# Altium schematic sheet USB3_Device.SchDoc
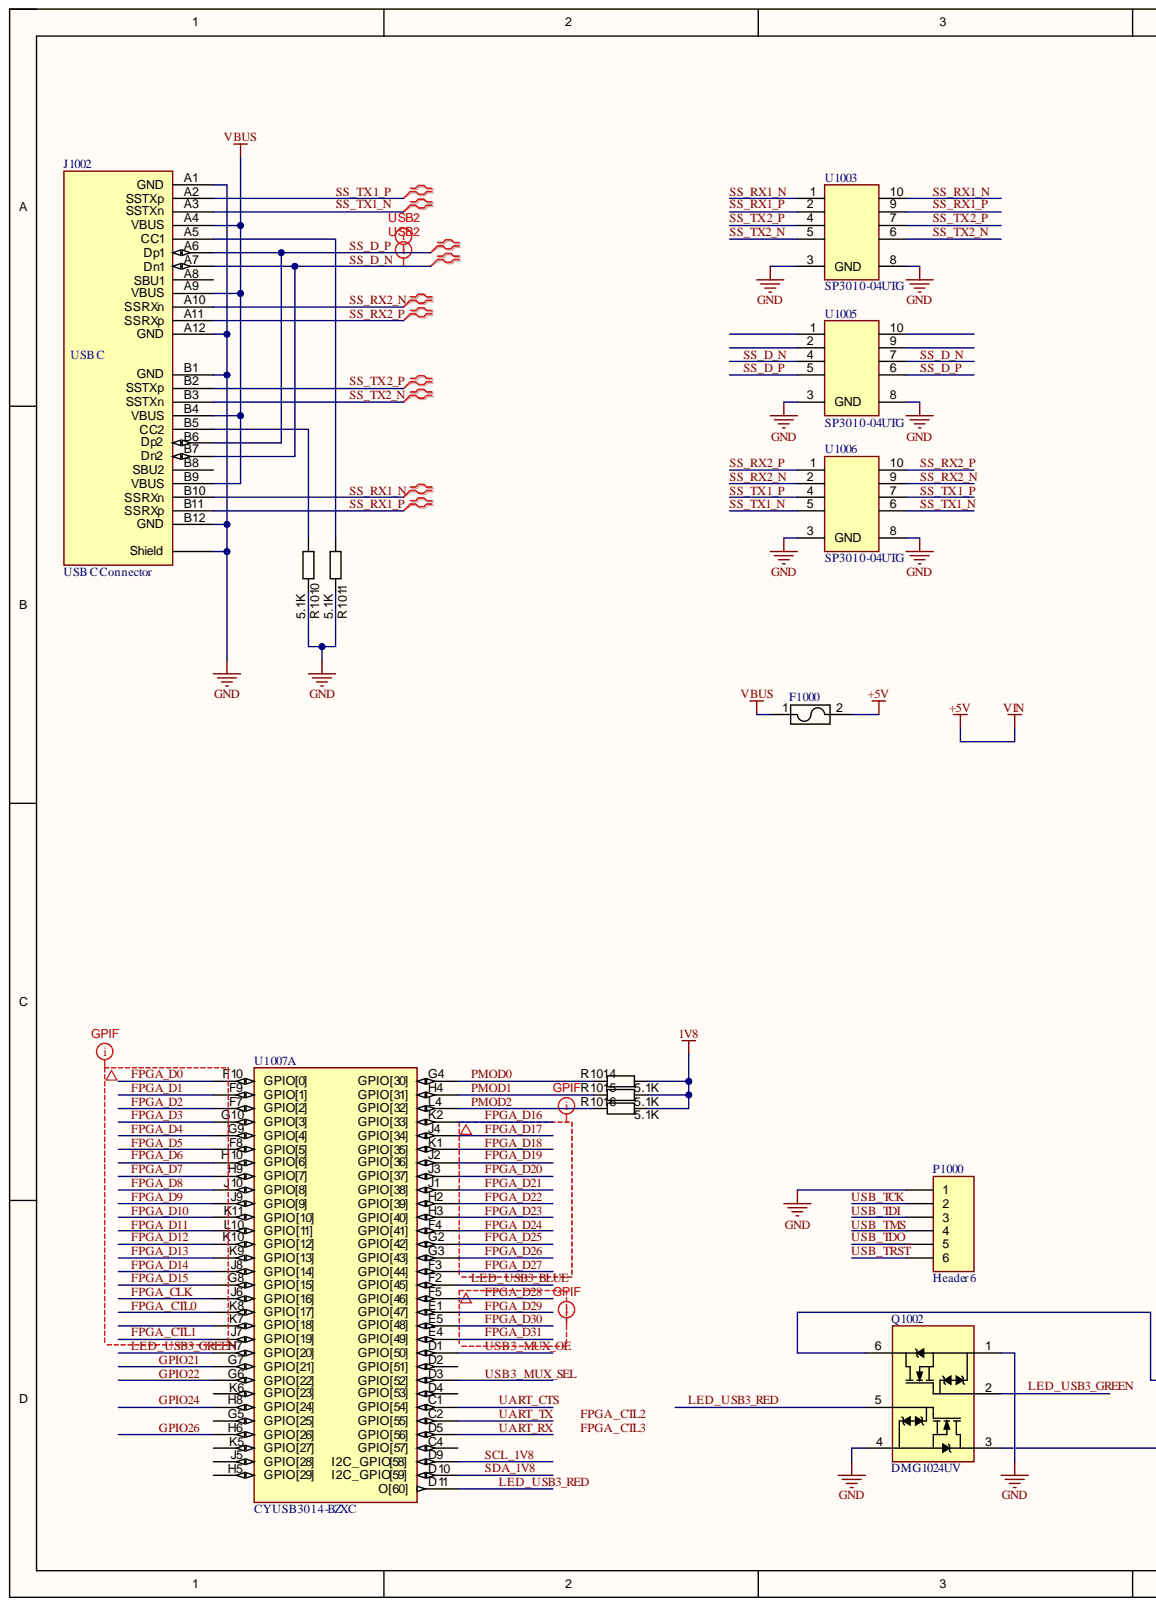
[diagram p0: USB3_Device.SchDoc, left]
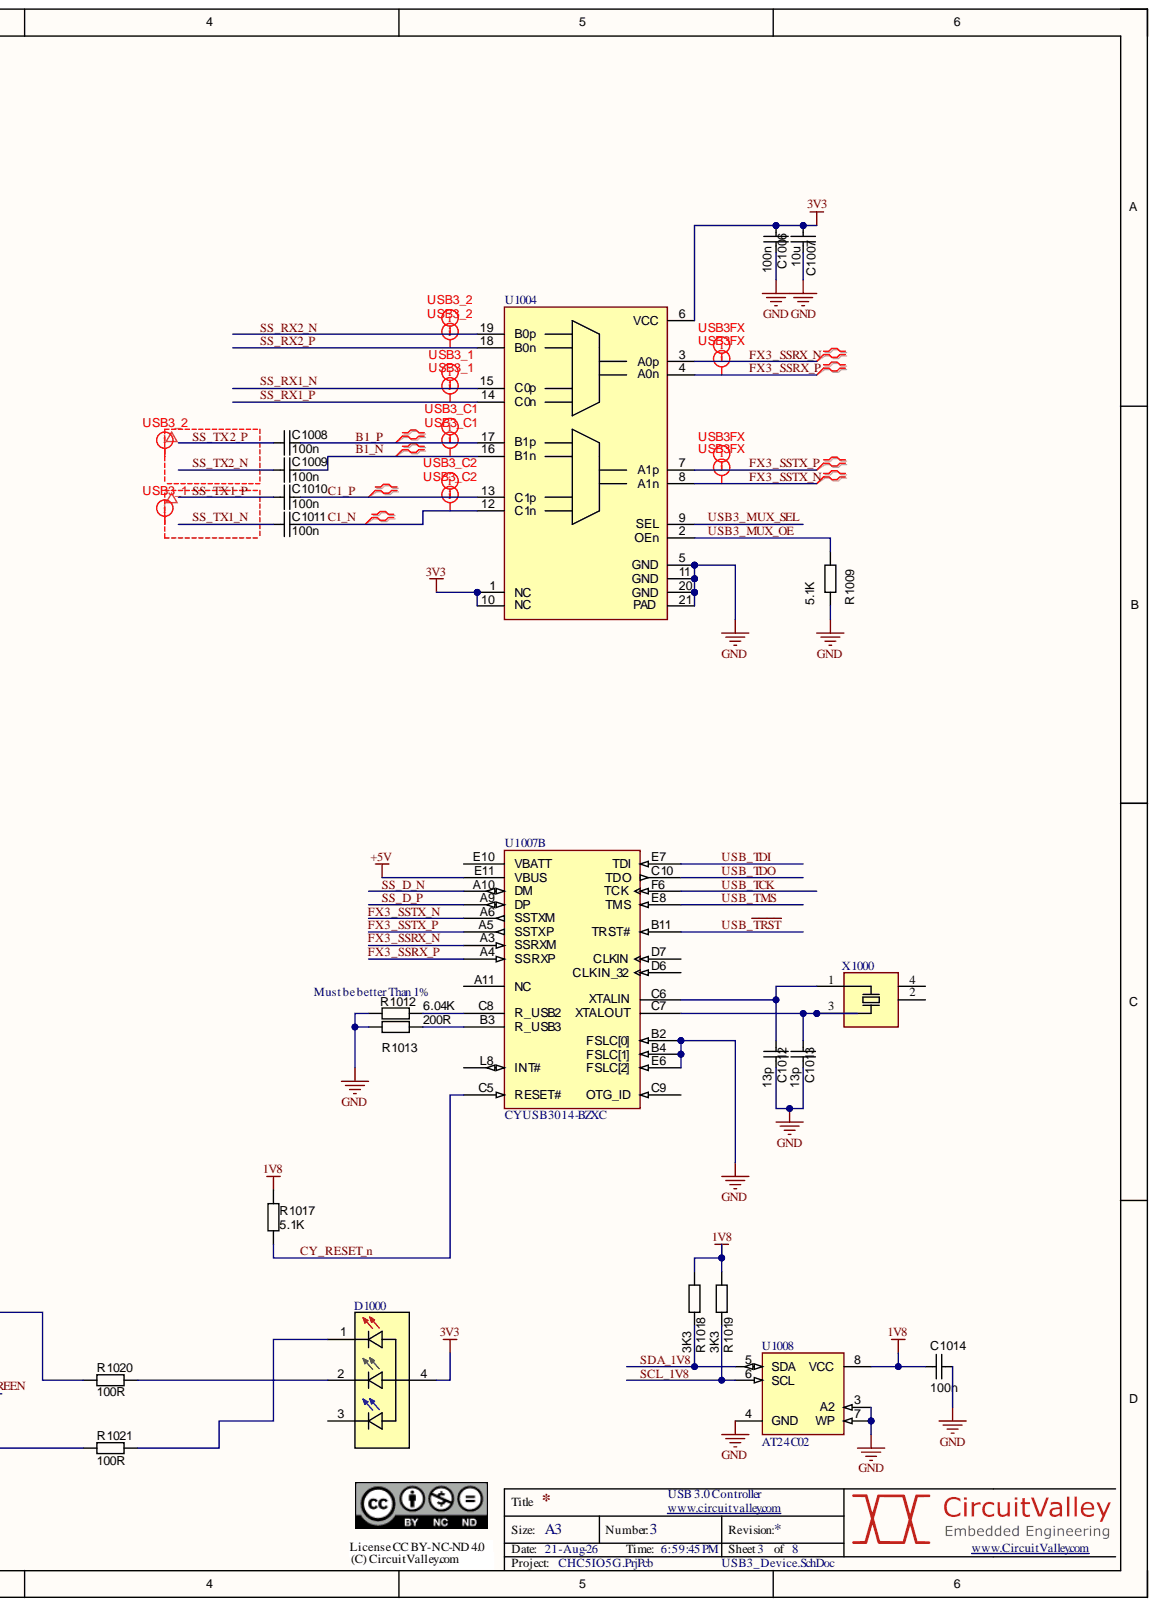
[diagram p1: USB3_Device.SchDoc, right]

## Parts
C1006  100n  [CAPC1005N]
C1007  value 10u  [CAPC1608N]  (hidden comment: approved sub OK)
C1008  100n  [CAPC1005N]
C1009  100n  [CAPC1005N]
C1010  100n  [CAPC1005N]
C1011  100n  [CAPC1005N]
C1012  13p  [CAPC1005N]
C1013  13p  [CAPC1005N]
C1014  100n  [CAPC1005N]
D1000  LTST-C19HE1WT  [0606]  (value not drawn)
F1000  0467005.NRHF  [0467005.NRHF]  (value not drawn)
J1002  USB C Connector  [GCT_USB4115-03-C_REVB4]
P1000  Header 6  [SMD_Solder_points_1.27]
Q1002  DMG1024UV  [SOT563]
R1009  value 5.1K  [RESC1005N]  (hidden comment: Thick Film)
R1010  value 5.1K  [RESC1005N]  (hidden comment: Thick Film)
R1011  value 5.1K  [RESC1005N]  (hidden comment: Thick Film)
R1012  value 6.04K  [RESC1005N]  (hidden comment: Thick Film)
R1013  value 200R  [RESC1005N]  (hidden comment: Thick Film)
R1014  value 5.1K  [RESC1005N]  (hidden comment: Thick Film)
R1015  value 5.1K  [RESC1005N]  (hidden comment: Thick Film)
R1016  value 5.1K  [RESC1005N]  (hidden comment: Thick Film)
R1017  value 5.1K  [RESC1005N]  (hidden comment: Thick Film)
R1018  value 3K3  [RESC1005N]  (hidden comment: Thick Film)
R1019  value 3K3  [RESC1005N]  (hidden comment: Thick Film)
R1020  value 100R  [RESC1005N]  (hidden comment: Thick Film)
R1021  value 100R  [RESC1005N]  (hidden comment: Thick Film)
U1003  SP3010-04UTG  [uDFN-10]
U1004  TMUXHS4212  [VQFN_RKS0020A]  (value not drawn)
U1005  SP3010-04UTG  [uDFN-10]
U1006  SP3010-04UTG  [uDFN-10]
U1007  CYUSB3014-BZXC  [CYP-BZX121]  (parts A,B)
U1008  AT24C02  [SOIC8]
X1000  ECS-192-7-36B-CKY-TR  [Active Oscillator 2520]  (value not drawn)

## Nets
1V8: C1014.2 R1014.2 R1015.2 R1016.2 R1017.1 R1018.1 R1019.1 U1008.8(VCC)
3V3: C1006.2 C1007.1 D1000.4 U1004.1(NC) U1004.6(VCC) U1004.10(NC)
+5V: F1000.2 U1007.E11(VBUS)
GND: C1006.1 C1007.2 C1012.1 C1013.1 C1014.1 J1002.0(Shield) J1002.A1(GND) J1002.A12(GND) J1002.B1(GND) J1002.B12(GND) P1000.1 Q1002.1 Q1002.4 R1009.2 R1010.2 R1011.2 R1012.1 R1013.1 U1003.3(GND) U1003.8 U1004.5(GND) U1004.11(GND) U1004.20(GND) U1004.21(PAD) U1005.3(GND) U1005.8 U1006.3(GND) U1006.8 U1007.B2(FSLC[0]) U1007.B4(FSLC[1]) U1007.E6(FSLC[2]) U1008.3(A2) U1008.4(GND) U1008.7(WP)
VBUS: F1000.1 J1002.A4(VBUS) J1002.A9(VBUS) J1002.B4(VBUS) J1002.B9(VBUS)
B1_N: C1009.2 U1004.16(B1n)
B1_P: C1008.2 U1004.17(B1p)
C1_N: C1011.2 U1004.12(C1n)
C1_P: C1010.2 U1004.13(C1p)
CY_RESET_n: R1017.2 U1007.C5(RESET#)
FPGA_CLK: U1007.J6(GPIO[16])
FPGA_CTL0: U1007.K8(GPIO[17])
FPGA_CTL1: U1007.J7(GPIO[19])
FPGA_D0: U1007.F10(GPIO[0])
FPGA_D1: U1007.F9(GPIO[1])
FPGA_D2: U1007.F7(GPIO[2])
FPGA_D3: U1007.G10(GPIO[3])
FPGA_D4: U1007.G9(GPIO[4])
FPGA_D5: U1007.F8(GPIO[5])
FPGA_D6: U1007.H10(GPIO[6])
FPGA_D7: U1007.H9(GPIO[7])
FPGA_D8: U1007.J10(GPIO[8])
FPGA_D9: U1007.J9(GPIO[9])
FPGA_D10: U1007.K11(GPIO[10])
FPGA_D11: U1007.L10(GPIO[11])
FPGA_D12: U1007.K10(GPIO[12])
FPGA_D13: U1007.K9(GPIO[13])
FPGA_D14: U1007.J8(GPIO[14])
FPGA_D15: U1007.G8(GPIO[15])
FPGA_D16: U1007.K2(GPIO[33])
FPGA_D17: U1007.J4(GPIO[34])
FPGA_D18: U1007.K1(GPIO[35])
FPGA_D19: U1007.J2(GPIO[36])
FPGA_D20: U1007.J3(GPIO[37])
FPGA_D21: U1007.J1(GPIO[38])
FPGA_D22: U1007.H2(GPIO[39])
FPGA_D23: U1007.H3(GPIO[40])
FPGA_D24: U1007.F4(GPIO[41])
FPGA_D25: U1007.G2(GPIO[42])
FPGA_D26: U1007.G3(GPIO[43])
FPGA_D27: U1007.F3(GPIO[44])
FPGA_D28: U1007.F5(GPIO[46])
FPGA_D29: U1007.E1(GPIO[47])
FPGA_D30: U1007.E5(GPIO[48])
FPGA_D31: U1007.E4(GPIO[49])
FX3_SSRX_N: U1004.3(A0p) U1007.A3(SSRXM)
FX3_SSRX_P: U1004.4(A0n) U1007.A4(SSRXP)
FX3_SSTX_N: U1004.8(A1n) U1007.A6(SSTXM)
FX3_SSTX_P: U1004.7(A1p) U1007.A5(SSTXP)
GPIO21: U1007.G7(GPIO[21])
GPIO22: U1007.G6(GPIO[22])
GPIO24: U1007.H8(GPIO[24])
GPIO26: U1007.H6(GPIO[26])
LED_USB3_BLUE: U1007.F2(GPIO[45])
LED_USB3_GREEN: Q1002.2 U1007.H7(GPIO[20])
LED_USB3_RED: Q1002.5 U1007.D11(O[60])
PMOD0: R1014.1 U1007.G4(GPIO[30])
PMOD1: R1015.1 U1007.H4(GPIO[31])
PMOD2: R1016.1 U1007.L4(GPIO[32])
SCL_1V8: R1019.2 U1007.D9(I2C_GPIO[58]) U1008.6(SCL)
SDA_1V8: R1018.2 U1007.D10(I2C_GPIO[59]) U1008.5(SDA)
SS_D_N: J1002.A7(Dn1) J1002.B7(Dn2) U1005.4 U1005.7 U1007.A10(DM)
SS_D_P: J1002.A6(Dp1) J1002.B6(Dp2) U1005.5 U1005.6 U1007.A9(DP)
SS_RX1_N: J1002.B10(SSRXn) U1003.1 U1003.10 U1004.15(C0p)
SS_RX1_P: J1002.B11(SSRXp) U1003.2 U1003.9 U1004.14(C0n)
SS_RX2_N: J1002.A10(SSRXn) U1004.19(B0p) U1006.2 U1006.9
SS_RX2_P: J1002.A11(SSRXp) U1004.18(B0n) U1006.1 U1006.10
SS_TX1_N: C1011.1 J1002.A3(SSTXn) U1006.5 U1006.6
SS_TX1_P: C1010.1 J1002.A2(SSTXp) U1006.4 U1006.7
SS_TX2_N: C1009.1 J1002.B3(SSTXn) U1003.5 U1003.6
SS_TX2_P: C1008.1 J1002.B2(SSTXp) U1003.4 U1003.7
UART_CTS: U1007.C1(GPIO[54])
UART_RX: U1007.D5(GPIO[56])
UART_TX: U1007.C2(GPIO[55])
USB3_MUX_OE: R1009.1 U1004.2(OEn) U1007.D1(GPIO[50])
USB3_MUX_SEL: U1004.9(SEL) U1007.D3(GPIO[52])
USB_~{TRST}: P1000.6 U1007.B11(TRST#)
USB_TCK: P1000.2 U1007.F6(TCK)
USB_TDI: P1000.3 U1007.E7(TDI)
USB_TDO: P1000.5 U1007.C10(TDO)
USB_TMS: P1000.4 U1007.E8(TMS)
NetC1012_2: C1012.2 U1007.C6(XTALIN) X1000.1
NetC1013_2: C1013.2 U1007.C7(XTALOUT) X1000.3
NetD1000_1: D1000.1 R1021.2
NetD1000_2: D1000.2 R1020.2
NetJ1002_A5: J1002.A5(CC1) R1011.1
NetJ1002_B5: J1002.B5(CC2) R1010.1
NetQ1002_3: Q1002.3 R1021.1
NetQ1002_6: Q1002.6 R1020.1
NetR1012_2: R1012.2 U1007.C8(R_USB2)
NetR1013_2: R1013.2 U1007.B3(R_USB3)
FPGA_CTL2:
FPGA_CTL3:

Not connected: D1000 3; J1002 A8(SBU1) B8(SBU2); U1005 1 2 9 10; U1007 A11(NC) C4(GPIO[57]) C9(OTG_ID) D2(GPIO[51]) D4(GPIO[53]) D6(CLKIN_32) D7(CLKIN) E10(VBATT) G5(GPIO[25]) H5(GPIO[29]) J5(GPIO[28]) K5(GPIO[27]) K6(GPIO[23]) K7(GPIO[18]) L8(INT#); X1000 2 4

## Library data of the parts
C1006 C1008-C1014 = CAPC_10n_0402_16V_10%: Capacitor SMD Cer 10nF 10% 16V X7R 0402; Manufacturer 1: AVX Corporation; Manufacturer Part Number 1: 0402YC103KAT2A; Ratings: 16V; X7R; Component Kind: Standard; ComponentLink1Description: http://www.avx.com/docs/Catalogs/cx7r.pdf; ComponentLink1URL: http://www.avx.com/docs/Catalogs/cx7r.pdf; ComponentLink2Description: http://www.yageo.com/documents/recent/UPY-GPHC_X7R_6.3V-to-50V_8.pdf; ComponentLink2URL: http://www.yageo.com/documents/recent/UPY-GPHC_X7R_6.3V-to-50V_8.pdf; Library Name: PBL - Capacitors.SCHLIB; Manufacturer 2: Yageo; Manufacturer Part Number 2: CC0402KRX7R7BB103; Operating Temperature: -55°C ~ 125°C; Package / Case: 0402 (1005 Metric); Size / Dimension: 0.039" L x 0.020" W (1.00mm x 0.50mm); Supplier 1: Digi-Key; Supplier 2: Digi-Key; Supplier Part Number 1: 478-1114-1-ND; Supplier Part Number 2: 311-1042-1-ND; Tolerance: ±10%
  C1006 C1008-C1011 C1014: Value: 100n
  C1012 C1013: Value: 13p
C1007 = CAPC_560p_0603_5%: Capacitor SMD Cer 560pF 5% 50V NP0 0603; Manufacturer 1: AVX Corporation; Manufacturer Part Number 1: 06035A561JAT2A; Capacitance: 470pF; Material: ceramic; Ratings: 50V; NP0; Applications: General Purpose; Component Kind: Standard; ComponentLink1Description: http://www.avx.com/docs/Catalogs/ccog.pdf; ComponentLink1URL: http://www.avx.com/docs/Catalogs/ccog.pdf; Height - Seated (Max): -; Lead Spacing: -; Lead Style: -; Library Name: PBL - Capacitors.SCHLIB; Mounting Type: Surface Mount, MLCC; Operating Temperature: -55°C ~ 125°C; Package / Case: 0603 (1608 metric); Size / Dimension: 0.063" L x 0.032" W (1.60mm x 0.81mm); Supplier 1: Digi-Key; Supplier Part Number 1: 478-1184-1-ND; Thickness (Max): 0.035" (0.90mm); Voltage - Rated: 50V
D1000 = RGBLED: Component Kind: Standard; Description: LTST-C19HE1WT; Library Name: cvlib.SchLib
F1000 = Fuse: Component Kind: Standard; Description: 0467005.NRHF; Library Name: cvlib.SchLib
J1002 = USB_C: Value: USB4115-03-C; Component Kind: Standard; Description: USB C connector; Library Name: cvlib.SchLib
P1000 = Header 6: Header, 6-Pin; Component Kind: Standard; LatestRevisionDate: 17-Jul-2002; LatestRevisionNote: Re-released for DXP Platform.; Library Name: Miscellaneous Connectors.IntLib; Signal Integrity: Connector; Publisher: Altium Limited
R1009-R1021 = RES_3K3_0402_5%: Resistor SMD chip 3.3k Ohm 0.063W 5% 0402; Manufacturer 1: Yageo; Manufacturer Part Number 1: RC0402JR-073K3L; Ratings: 1/16 W; Component Kind: Standard; ComponentLink1Description: http://www.yageo.com/documents/recent/PYu-RC0402_51_RoHS_L_6.pdf; ComponentLink1URL: http://www.yageo.com/documents/recent/PYu-RC0402_51_RoHS_L_6.pdf; Library Name: PBL - Resistors.SCHLIB; Material: Thick Film; Package / Case: 0402 (1005 metric); Supplier 1: Digi-Key; Supplier Part Number 1: 311-3.3KJRCT-ND; Tolerance: ±5%
U1003 U1005 U1006 = SP3010-04UTG: ESD Protection; Component Kind: Standard; Library Name: cvlib.SchLib
U1007 = CYUSB3014-BZXC: EZ-USB(R) FX3: SuperSpeed USB Controller, 512 KB, 60 I/Os, 0 to 70 degC, 121-Pin FBGA (BZX121); Manufacturer: Cypress; CPU Core: ARM926 EJ-S; Max. Operating Voltage (V): 1.25; Memory Architecture: SRAM; Memory Size (KB): 512; Min. Operating Voltage (V): 1.15; No. of I/Os: 60; Code_JEDEC: PUB 95; Component Kind: Standard; ComponentLink1Description: Manufacturer URL; ComponentLink1URL: http://www.cypress.com/; ComponentLink2Description: Datasheet; ComponentLink2URL: http://www.cypress.com/?docID=49087; ComponentLink3Description: Package Specification; ComponentLink3URL: http://www.cypress.com/?docID=45795; DatasheetVersion: Rev. *O; Library Name: Cypress USB Controllers.SchLib; Max. Operating Temp. (degreesC): 70; Min. Operating Temp. (degreesC): 0; Mounting Technology: Surface Mount; PackageDescription: 121-Ball FBGA, Body 10 x 10 mm, Pitch 0.8 mm; PackageReference: BZX121; PackageVersion: Rev. *D; PartNumber: CYUSB3014-BZXC; RoHS: Pb-Free; Supplier 1: Digi-Key; Supplier 2: Farnell; Supplier 3: Newark; Supplier 4: Mouser; Supplier Part Number 1: 428-3182-ND; Supplier Part Number 2: 2355789; Supplier Part Number 3: 54W7901; Supplier Part Number 4: 727-CYUSB3014-BZXC
U1008 = AT24CM02: 2Mbit I2C EEPROM; Part: C115042; Component Kind: Standard; Library Name: cvlib.SchLib
X1000 = Crystal: Crystal Oscillator; Component Kind: Standard; Library Name: cvlib.SchLib
Q1002 = DMG1024UV: Component Kind: Standard; Description: DMG1024UV; Library Name: cvlib.SchLib
U1004 = TMUXHS4212: Component Kind: Standard; Library Name: cvlib.SchLib
